# Altium schematic sheet [25] - FAN  CONTROLLER.SchDoc("[25] - FAN  CONTROLLER")
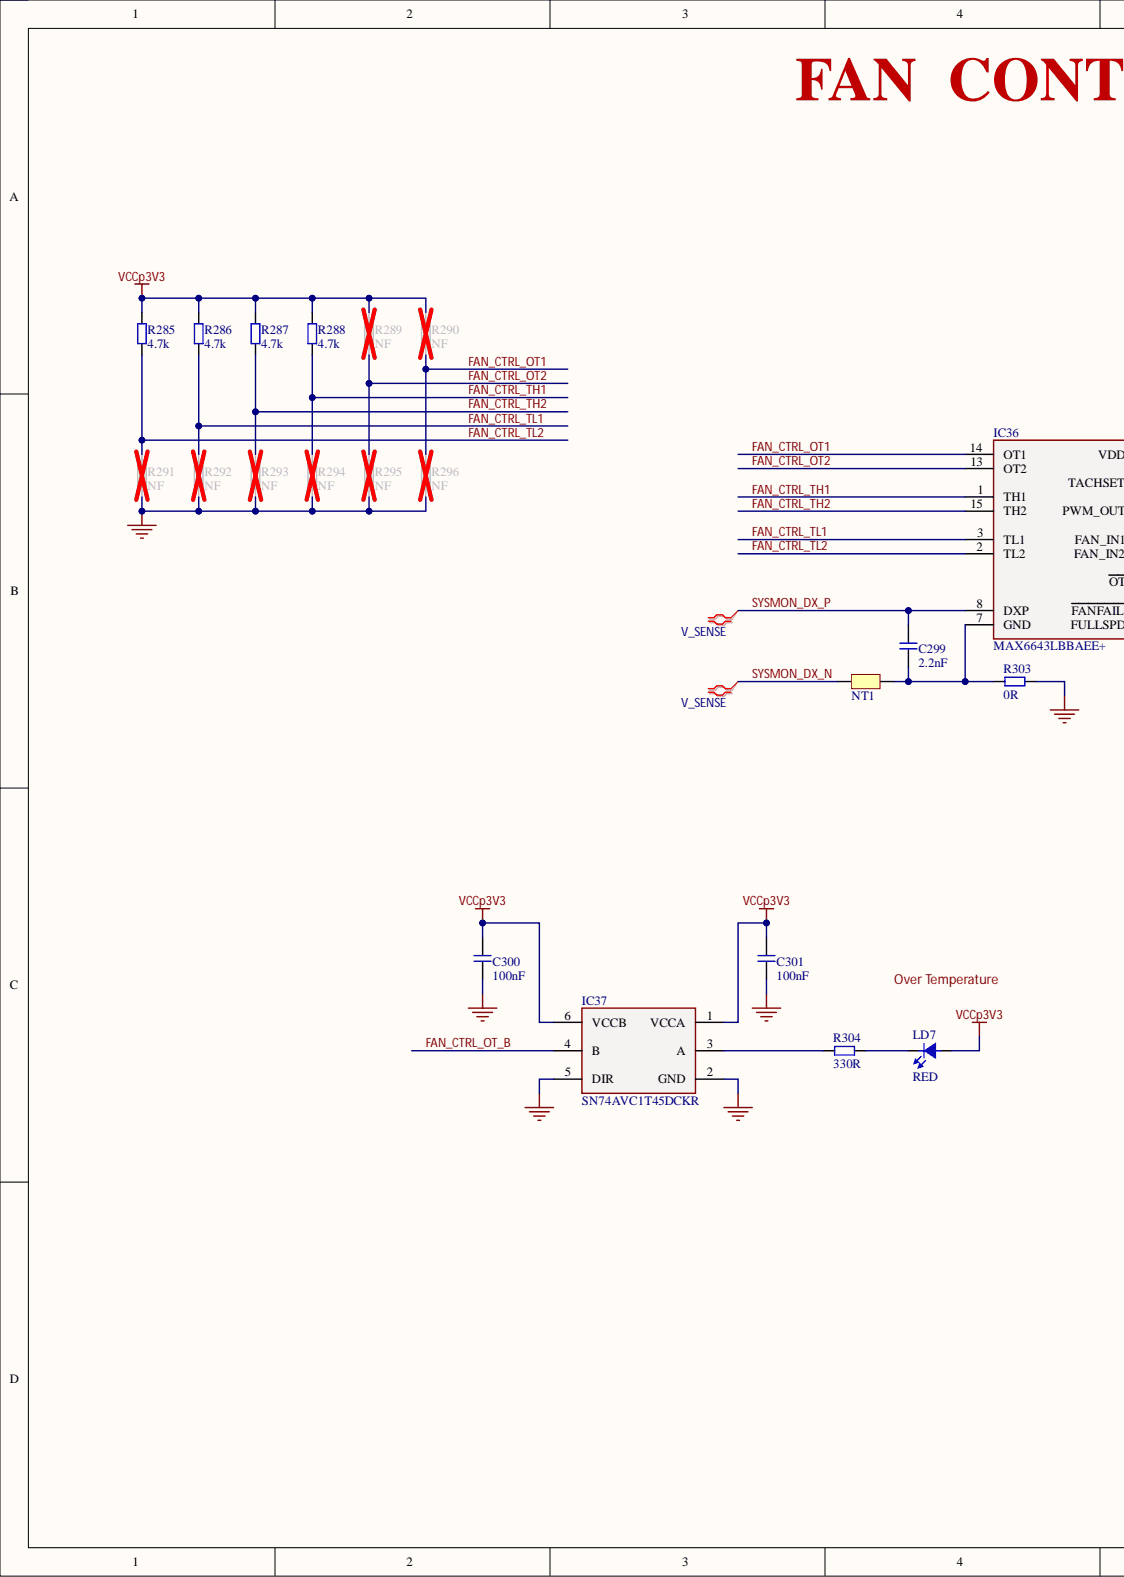
[diagram p0: [25] - FAN  CONTROLLER.SchDoc, left]
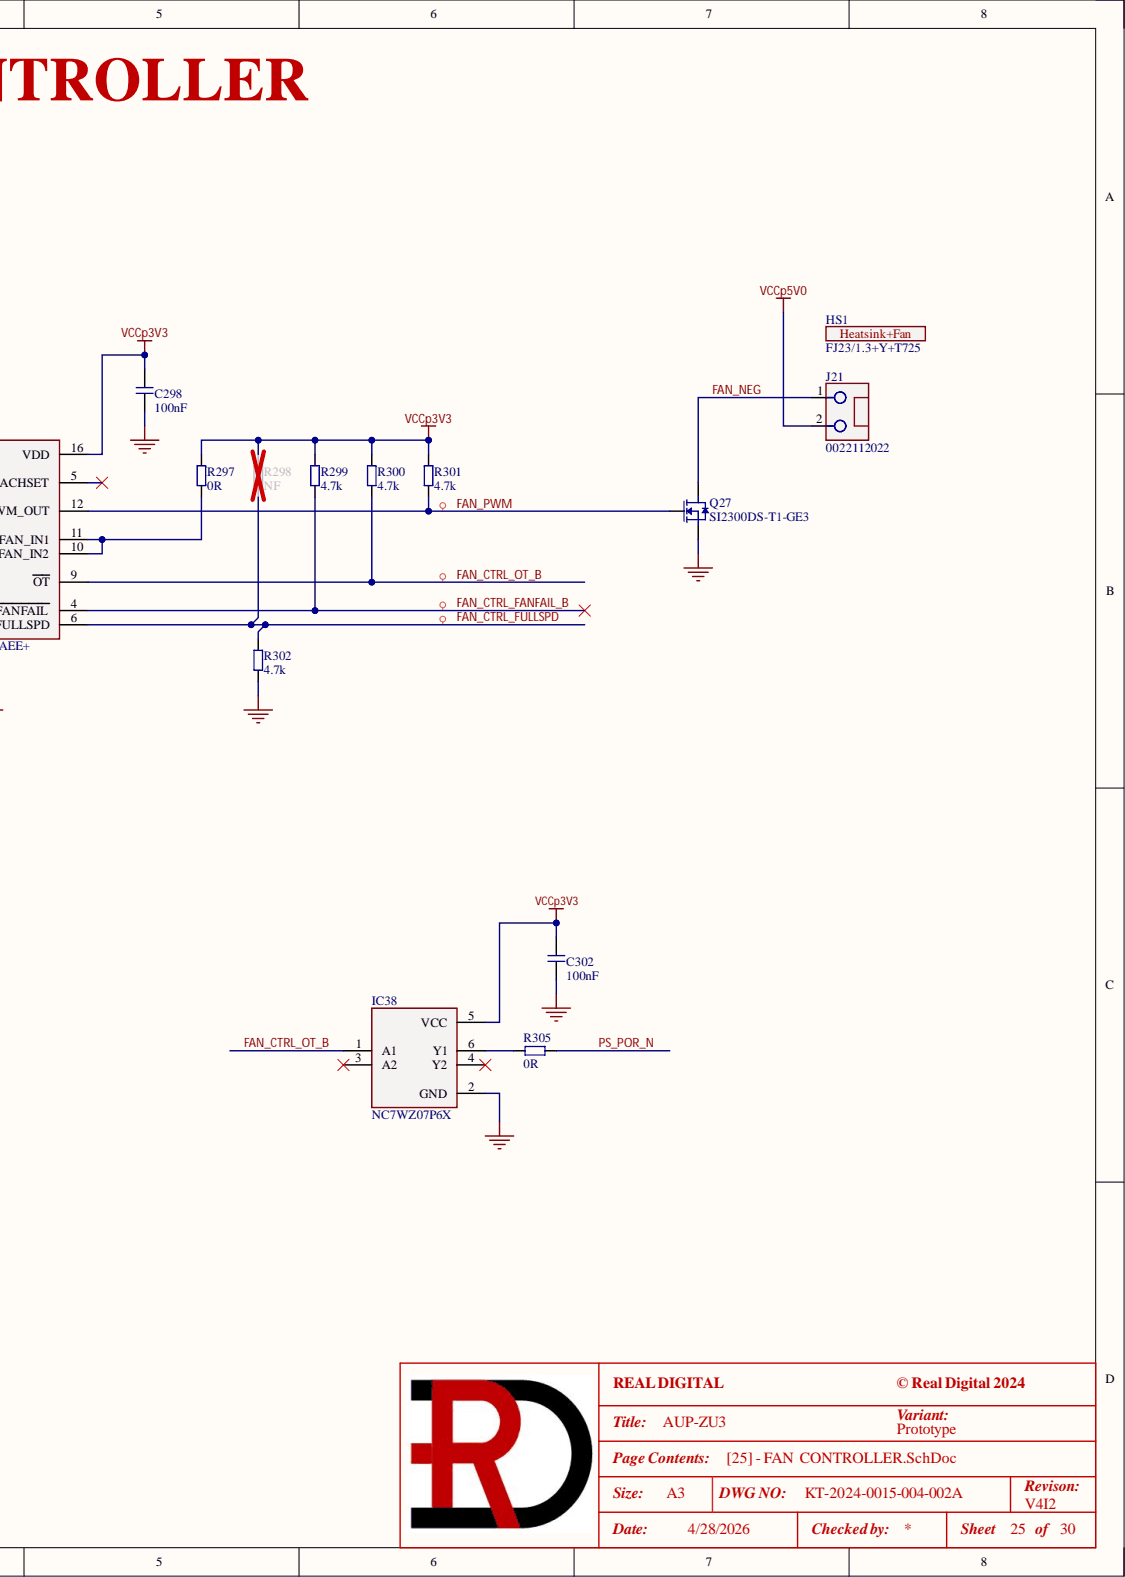
[diagram p1: [25] - FAN  CONTROLLER.SchDoc, right]

## Parts
C298  100nF  [C0402]
C299  2.2nF  [C0402]
C300  100nF  [C0402]
C301  100nF  [C0402]
C302  100nF  [C0402]
HS1  FJ23/1.3+Y+T725  [FJ23/1.3+Y+T725_NoFan]
IC36  MAX6643LBBAEE+  [21-0055H_16 - MAXIM]
IC37  SN74AVC1T45DCKR  [DCK0006A_SC70-6 - TI]
IC38  NC7WZ07P6X  [DCK0006A_SC70-6 - TI]
J21  0022112022  [0022112022]
LD7  RED  [LD0603_RED]
NT1  Net-Tie  [Net-Tie_0.25mmWide_0.25mmLong]  (value not drawn)  (no BOM)
Q27  SI2300DS-T1-GE3  [SOT-23-3]
R285  4.7k  [R0402]
R286  4.7k  [R0402]
R287  4.7k  [R0402]
R288  4.7k  [R0402]
R289  NF  [R0402]
R290  NF  [R0402]
R291  NF  [R0402]
R292  NF  [R0402]
R293  NF  [R0402]
R294  NF  [R0402]
R295  NF  [R0402]
R296  NF  [R0402]
R297  0R  [R0402]
R298  NF  [R0402]
R299  4.7k  [R0402]
R300  4.7k  [R0402]
R301  4.7k  [R0402]
R302  4.7k  [R0402]
R303  0R  [R0402]
R304  330R  [R0402]
R305  0R  [R0402]

## Nets
GND: C298.1 C300.1 C301.1 C302.1 IC37.2(GND) IC37.5(DIR) IC38.2(GND) Q27.2 R291.1 R292.1 R293.1 R294.1 R295.1 R296.1 R302.1 R303.2
VCCp3V3: C298.2 C300.2 C301.2 C302.2 IC36.16(VDD) IC37.1(VCCA) IC37.6(VCCB) IC38.5(VCC) LD7.A R285.2 R286.2 R287.2 R288.2 R289.2 R290.2 R297.2 R298.2 R299.2 R300.2 R301.2
VCCp5V0: J21.2
FAN_CTRL_FANFAIL_B: IC36.4(FANFAIL) R299.1
FAN_CTRL_FULLSPD: IC36.6(FULLSPD) R298.1 R302.2
FAN_CTRL_OT1: IC36.14(OT1) R290.1 R296.2
FAN_CTRL_OT2: IC36.13(OT2) R289.1 R295.2
FAN_CTRL_OT_B: IC36.9(OT) IC37.4(B) IC38.1(A1) R300.1
FAN_CTRL_TH1: IC36.1(TH1) R288.1 R294.2
FAN_CTRL_TH2: IC36.15(TH2) R287.1 R293.2
FAN_CTRL_TL1: IC36.3(TL1) R286.1 R292.2
FAN_CTRL_TL2: IC36.2(TL2) R285.1 R291.2
FAN_NEG: J21.1 Q27.3
FAN_PWM: IC36.12(PWM_OUT) Q27.1 R301.1
PS_POR_N: R305.2
SYSMON_DX_N: NT1.1
SYSMON_DX_P: C299.2 IC36.8(DXP)
NetC299_1: C299.1 IC36.7(GND) NT1.2 R303.1
NetIC36_10: IC36.10(FAN_IN2) IC36.11(FAN_IN1) R297.1
NetIC37_3: IC37.3(A) R304.2
NetIC38_6: IC38.6(Y1) R305.1
NetLD7_K: LD7.K R304.1

Not connected: IC36 5(TACHSET); IC38 3(A2) 4(Y2)

## Library data of the parts
C298 C300-C302 = GRM155R71E104KE14J: CAP CER 0.1uF 25V X7R 0402; Manufacturer 1: Murata Electronics; .Checked_PCB: AC; .Creator: RV; C P/N: RD-111-0006; LoadStatus: Load; Tolerance: 10%; Category: Capacitors; Component Kind: Standard; Library Name: KT-RealDigital.IntLib; Manufacturer 1 Part Number: GRM155R71E104KE14J; Temperature Coefficient: X7R; Voltage - Rated: 25V; .Checked_SCH: AC
C299 = GRM155R71H222JA01J: CAP CER 2200pF 50V X7R 0402; Manufacturer 1: Murata Electronics; .Checked_PCB: RV; C P/N: RD-111-0028; LoadStatus: Load; Tolerance: 5%; Category: Capacitors; Component Kind: Standard; Library Name: KT-RealDigital.IntLib; Manufacturer 1 Part Number: GRM155R71H222JA01J; Temperature Coefficient: X7R; Voltage - Rated: 50V; .Checked_SCH: RV; .Creator: RV
HS1 = FJ23/1.3+Y+T725: Clipon Fansink, 23mm BGA 5V Fansink; Manufacturer 1: Radian Thermal Products, Inc.; .Checked_PCB: RV; C P/N: RD-170-0029; LoadStatus: Load; Category: Resistors; Component Kind: Standard; Library Name: KT-RealDigital.IntLib; Manufacturer 1 Part Number: FJ23/1.3+Y+T725; .Checked_SCH: RV; .Creator: RV
IC36 = MAX6643LBBAEE+: IC CNTRLR FAN SPEED 16-QSOP; Manufacturer 1: Maxim Integrated; .Checked_PCB: RV; C P/N: RD-126-0026; LoadStatus: Load; Category: IC; Component Kind: Standard; Library Name: KT-RealDigital.IntLib; Manufacturer 1 Part Number: MAX6643LBBAEE+; .Checked_SCH: RV; .Creator: RV
IC37 = SN74AVC1T45DCKR: IC TRNSLTR BIDIRECTIONAL SC70-6; Manufacturer 1: Texas Instruments; .Checked_PCB: RV; C P/N: RD-126-0010; LoadStatus: Load; Category: IC; Component Kind: Standard; Library Name: KT-RealDigital.IntLib; Manufacturer 1 Part Number: SN74AVC1T45DCKR; .Checked_SCH: RV; .Creator: RV
IC38 = NC7WZ07P6X: IC BUF NON-INVERT 5.5V SC70-6; Manufacturer 1: ON Semiconductor; .Checked_PCB: RV; C P/N: 126-0507; LoadStatus: Load; Category: IC; Component Kind: Standard; Library Name: KT-RealDigital.IntLib; Manufacturer 1 Part Number: NC7WZ07P6X; .Checked_SCH: RV; .Creator: RV
J21 = 0022112022: CONN HEADER VERT 2POS 2.54MM; Manufacturer 1: Molex; .Checked_PCB: RV; C P/N: RD-163-0006; LoadStatus: Load; Category: Header; Component Kind: Standard; Library Name: KT-RealDigital.IntLib; Manufacturer 1 Part Number: 0022112022; .Checked_SCH: RV; .Creator: RV
LD7 = LED_RED: LED RED 0603; Manufacturer 1: EVERLIGHT ELECTRONICS CO.,LTD.; Manufacturer 1 Part Number: 19-21SURC/S530-XX/TR8; .Checked_PCB: AC; .Creator: RV; C P/N: 121-0001; LoadStatus: Load; Category: Led; Component Kind: Standard; Library Name: KT-RealDigital.IntLib; .Checked_SCH: AC
NT1 = NetTie: Single point connection between nets.; .Checked_PCB: RV; C P/N: NA; Category: NA; KT P/N: NA; Library Name: KT-RealDigital.IntLib; LoadStatus: NA; Manufacturer 1: NA; Manufacturer 1 Part Number: NA; Manufacturer 2: NA; Manufacturer 2 Part Number: NA; .Checked_SCH: RV; .Creator: RV
Q27 = SI2300DS-T1-GE3: MOSFET N-CH 30V 3.6A SOT-23; Manufacturer 1: Vishay Siliconix; .Checked_PCB: RV; C P/N: 121-0303; LoadStatus: Load; Category: MOSFETs; Component Kind: Standard; Library Name: KT-RealDigital.IntLib; Manufacturer 1 Part Number: SI2300DS-T1-GE3; .Checked_SCH: RV; .Creator: RV
R285-R288 R299-R302 = RC0402FR-074K7P: RES SMD 4.7K OHM 1% 1/16W 0402; Manufacturer 1: Yageo; .Checked_PCB: AC; .Creator: RV; C P/N: 101-0129; LoadStatus: Load; Tolerance: 1%/TK100; Category: Resistors; Component Kind: Standard; Library Name: KT-RealDigital.IntLib; Manufacturer 1 Part Number: RC0402FR-074K7P; .Checked_SCH: AC
R289-R296 R298 = !RES0402: Generic Resistor, 0402; .Creator: RV; LoadStatus: Load; Category: Resistors; Component Kind: Standard; Library Name: KT-RealDigital.IntLib
R297 R303 R305 = RC0402FR-070RL: RES SMD 0 OHM JUMPER 1/16W 0402; Manufacturer 1: YAGEO; .Checked_PCB: AC; .Creator: RV; C P/N: RD-101-0003; LoadStatus: Load; Category: Resistors; Component Kind: Standard; Library Name: KT-RealDigital.IntLib; Manufacturer 1 Part Number: RC0402FR-070RL; Tolerance: Jumper; .Checked_SCH: AC
R304 = RC0402JR-07330RL: RES SMD 330 OHM 5% 1/16W 0402; Manufacturer 1: Yageo; .Checked_PCB: AC; .Creator: RV; C P/N: 101-0112; LoadStatus: Load; Tolerance: 5%/TK100; Category: Resistors; Component Kind: Standard; Library Name: KT-RealDigital.IntLib; Manufacturer 1 Part Number: RC0402JR-07330RL; .Checked_SCH: AC
